# Altium schematic sheet display.SchDoc
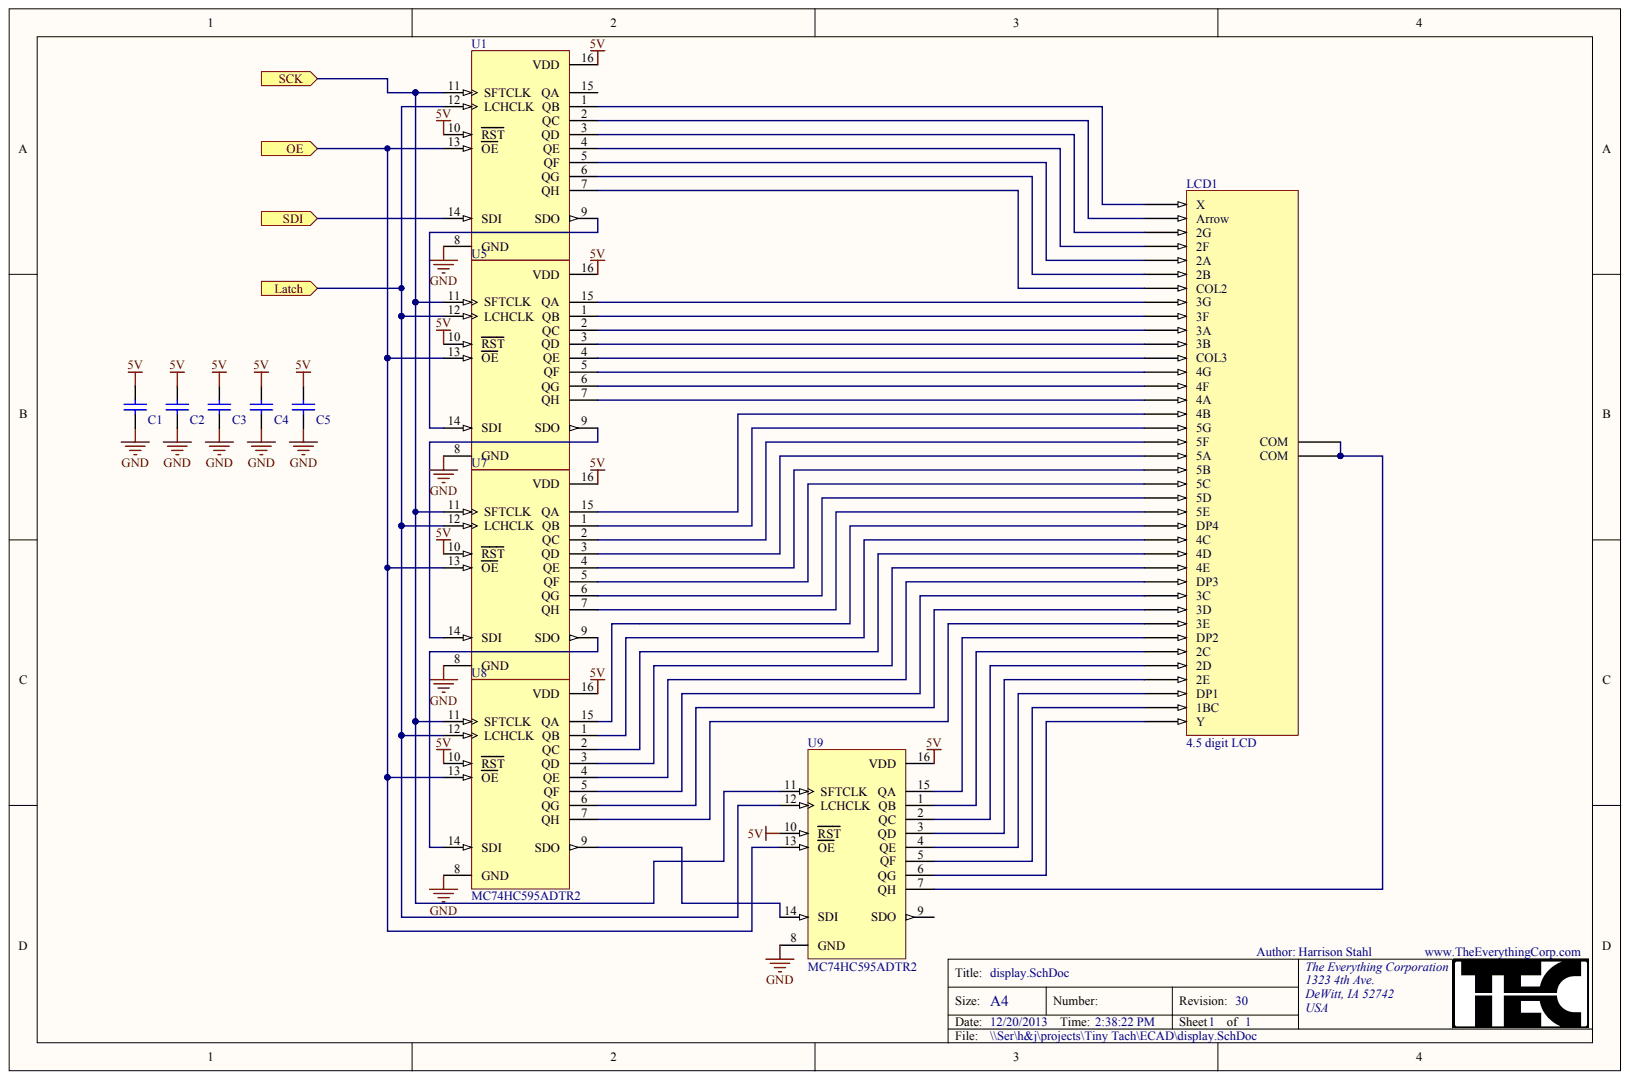
[diagram p0: display.SchDoc]

## Parts
C1  CAP 100nF 25V 0603(1608)  (value not drawn)
C2  CAP 100nF 25V 0603(1608)  (value not drawn)
C3  CAP 100nF 25V 0603(1608)  (value not drawn)
C4  CAP 100nF 25V 0603(1608)  (value not drawn)
C5  CAP 100nF 25V 0603(1608)  (value not drawn)
LCD1  4.5 digit LCD
U1  MC74HC595ADTR2
U5  MC74HC595ADTR2
U7  MC74HC595ADTR2
U8  MC74HC595ADTR2
U9  MC74HC595ADTR2

## Ports
LATCH, OE, SCK, SDI

## Nets
5V: C1.2 C2.2 C3.2 C4.2 C5.2 U1.10(RST) U1.16(VDD) U5.10(RST) U5.16(VDD) U7.10(RST) U7.16(VDD) U8.10(RST) U8.16(VDD) U9.10(RST) U9.16(VDD)
GND: C1.1 C2.1 C3.1 C4.1 C5.1 U1.8(GND) U5.8(GND) U7.8(GND) U8.8(GND) U9.8(GND)
OE: U1.13(OE) U5.13(OE) U7.13(OE) U8.13(OE) U9.13(OE) ; port OE
SCK: U1.11(SFTCLK) U5.11(SFTCLK) U7.11(SFTCLK) U8.11(SFTCLK) U9.11(SFTCLK) ; port SCK
SDI: U1.14(SDI) ; port SDI
NetLCD1_1: LCD1.1(COM) LCD1.40(COM) U9.7(QH)
NetLCD1_2: LCD1.2(Y) U9.6(QG)
NetLCD1_3: LCD1.3(1BC) U9.5(QF)
NetLCD1_4: LCD1.4(DP1) U9.4(QE)
NetLCD1_5: LCD1.5(2E) U9.3(QD)
NetLCD1_6: LCD1.6(2D) U9.2(QC)
NetLCD1_7: LCD1.7(2C) U9.1(QB)
NetLCD1_8: LCD1.8(DP2) U9.15(QA)
NetLCD1_9: LCD1.9(3E) U8.7(QH)
NetLCD1_10: LCD1.10(3D) U8.6(QG)
NetLCD1_11: LCD1.11(3C) U8.5(QF)
NetLCD1_12: LCD1.12(DP3) U8.4(QE)
NetLCD1_13: LCD1.13(4E) U8.3(QD)
NetLCD1_14: LCD1.14(4D) U8.2(QC)
NetLCD1_15: LCD1.15(4C) U8.1(QB)
NetLCD1_16: LCD1.16(DP4) U8.15(QA)
NetLCD1_17: LCD1.17(5E) U7.7(QH)
NetLCD1_18: LCD1.18(5D) U7.6(QG)
NetLCD1_19: LCD1.19(5C) U7.5(QF)
NetLCD1_20: LCD1.20(5B) U7.4(QE)
NetLCD1_21: LCD1.21(5A) U7.3(QD)
NetLCD1_22: LCD1.22(5F) U7.2(QC)
NetLCD1_23: LCD1.23(5G) U7.1(QB)
NetLCD1_24: LCD1.24(4B) U7.15(QA)
NetLCD1_25: LCD1.25(4A) U5.7(QH)
NetLCD1_26: LCD1.26(4F) U5.6(QG)
NetLCD1_27: LCD1.27(4G) U5.5(QF)
NetLCD1_28: LCD1.28(COL3) U5.4(QE)
NetLCD1_29: LCD1.29(3B) U5.3(QD)
NetLCD1_30: LCD1.30(3A) U5.2(QC)
NetLCD1_31: LCD1.31(3F) U5.1(QB)
NetLCD1_32: LCD1.32(3G) U5.15(QA)
NetLCD1_33: LCD1.33(COL2) U1.7(QH)
NetLCD1_34: LCD1.34(2B) U1.6(QG)
NetLCD1_35: LCD1.35(2A) U1.5(QF)
NetLCD1_36: LCD1.36(2F) U1.4(QE)
NetLCD1_37: LCD1.37(2G) U1.3(QD)
NetLCD1_38: LCD1.38(Arrow) U1.2(QC)
NetLCD1_39: LCD1.39(X) U1.1(QB)
NetU1_9: U1.9(SDO) U5.14(SDI)
NetU1_12: U1.12(LCHCLK) U5.12(LCHCLK) U7.12(LCHCLK) U8.12(LCHCLK) U9.12(LCHCLK) ; port LATCH
NetU5_9: U5.9(SDO) U7.14(SDI)
NetU7_9: U7.9(SDO) U8.14(SDI)
NetU8_9: U8.9(SDO) U9.14(SDI)

Not connected: U1 15(QA); U9 9(SDO)

## Library data of the parts
C1-C5 = (no symbol name): Case-Metric: 1608; Case-EIA: 0603; Tolerance: ±10%; Max Thickness: 1 mm; Technology: SMT; Rated Voltage: 25 V; Value: 100nF
LCD1 U1 U5 U7-U9 = (no symbol name)
  U1 U5 U7-U9: Published: 15-Apr-2002; LatestRevisionDate: 12-Dec-2006; LatestRevisionNote: IPC-7351 Footprint Added.; DatasheetVersion: Mar-2000; PackageVersion: Feb-2000; PackageReference: 948F-01; PackageDescription: 16-Pin Thin Small Outline Package 0.65 mm Pitch; ComponentLink1Description: Manufacturer Link; ComponentLink1URL: http://www.onsemi.com/; ComponentLink2Description: Datasheet; ComponentLink2URL: http://www.onsemi.com/pub/Collateral/MC74HC595A-D.PDF; Publisher: Altium Limited
